# Altium schematic sheet HWSD-POL V1.0.SchDoc
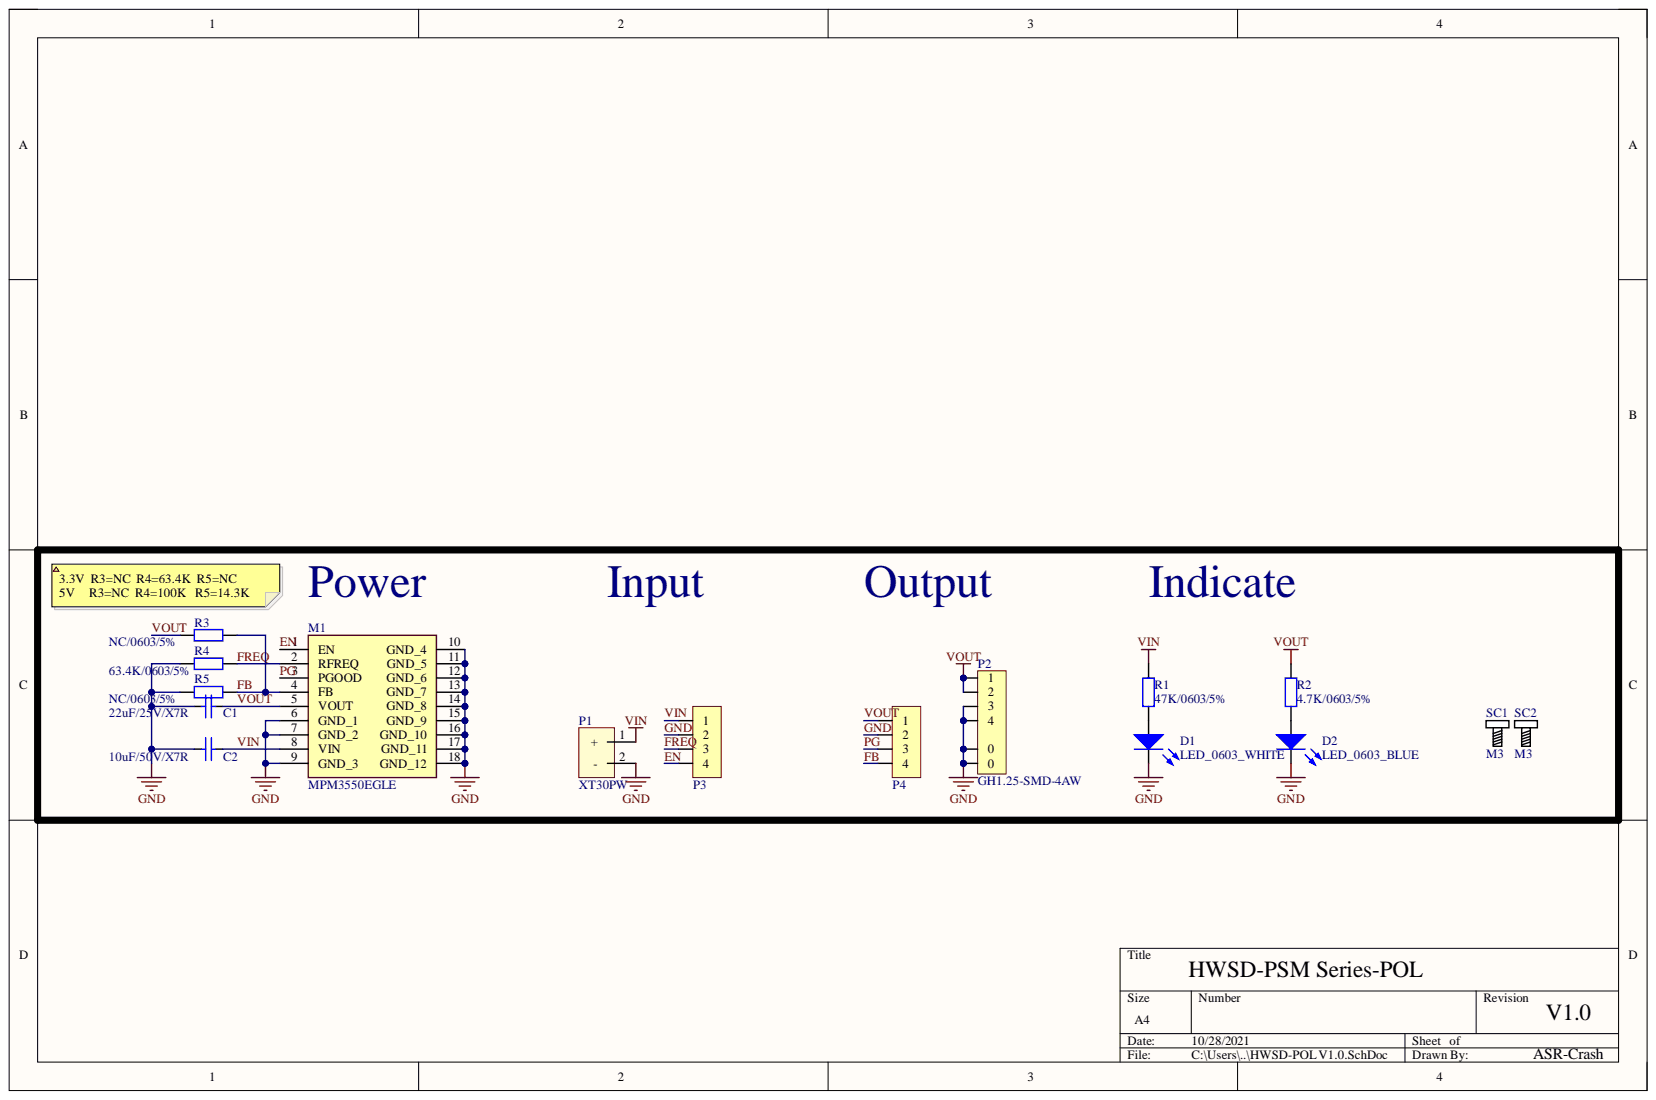
[diagram p0: HWSD-POL V1.0.SchDoc]

## Parts
C1  22uF/25V/X7R  [CAPC3225X270N]
C2  10uF/50V/X7R  [CAPC3225X270N]
D1  LED_0603_WHITE  [LED_0603_WHITE]
D2  LED_0603_BLUE  [LED_0603_BLUE]
M1  MPM3550EGLE  [MPM3550EGLE]
P1  XT30PW  [XT30PW-M]
P2  GH1.25-SMD-4AW  [GH1.25_4 卧贴]
P3  Header 4  [HDR1*4]  (value not drawn)
P4  Header 4  [HDR1*4]  (value not drawn)
R1  value 47K/0603/5%  [R-SMD-0603]  (hidden comment: Res_0603)
R2  value 4.7K/0603/5%  [R-SMD-0603]  (hidden comment: Res_0603)
R3  value NC/0603/5%  [R-SMD-0603]  (hidden comment: Res_0603)
R4  value 63.4K/0603/5%  [R-SMD-0603]  (hidden comment: Res_0603)
R5  value NC/0603/5%  [R-SMD-0603]  (hidden comment: Res_0603)
SC1  M3  [SCRREW_M3]
SC2  M3  [SCRREW_M3]

## Nets
EN: M1.1(EN) P3.4
FB: M1.4(FB) P4.4 R3.2 R5.2
FREQ: M1.2(RFREQ) P3.3 R4.2
GND: C1.1 C2.1 D1.2 D2.2 M1.6(GND_1) M1.7(GND_2) M1.9(GND_3) M1.10(GND_4) M1.11(GND_5) M1.12(GND_6) M1.13(GND_7) M1.14(GND_8) M1.15(GND_9) M1.16(GND_10) M1.17(GND_11) M1.18(GND_12) P1.2 P2.0 P2.3 P2.4 P3.2 P4.2 R4.1 R5.1
PG: M1.3(PGOOD) P4.3
VIN: C2.2 M1.8(VIN) P1.1 P3.1 R1.2
VOUT: C1.2 M1.5(VOUT) P2.1 P2.2 P4.1 R2.2 R3.1
NetD1_1: D1.1 R1.1
NetD2_1: D2.1 R2.1

## Library data of the parts
C1 = GRM32ER71E226KE15L: Capacitor; Manufacturer_Name: Murata Electronics; Arrow Part Number: GRM32ER71E226KE15L; Arrow Price/Stock: https://www.arrow.com/en/products/grm32er71e226ke15l/murata-manufacturing; Component Kind: Standard; Datasheet Link: http://psearch.en.murata.com/capacitor/product/GRM32ER71E226KE15%23.pdf; Height: 2.7mm; Manufacturer_Part_Number: GRM32ER71E226KE15L; Mouser Part Number: 81-GRM32ER71E226KE5L; Mouser Price/Stock: https://www.mouser.co.uk/ProductDetail/Murata-Electronics/GRM32ER71E226KE15L/?qs=xcCo%252BfWZmQXyfoWprNNimw%3D%3D; Library Name: Hardware Standardization Library.SchLib
C2 = GRM32ER71H106KA12L: Capacitor; Manufacturer_Name: Murata Electronics; Arrow Part Number: GRM32ER71H106KA12L; Arrow Price/Stock: https://www.arrow.com/en/products/grm32er71h106ka12l/murata-manufacturing; Component Kind: Standard; Datasheet Link: https://componentsearchengine.com/Datasheets/2/GRM32ER71H106KA12L.pdf; Height: 2.7mm; Manufacturer_Part_Number: GRM32ER71H106KA12L; Mouser Part Number: 81-GRM32ER71H106KA2L; Mouser Price/Stock: https://www.mouser.co.uk/ProductDetail/Murata-Electronics/GRM32ER71H106KA12L/?qs=6pxx44s1bjkjdm74o%2FrKhg%3D%3D; Library Name: Hardware Standardization Library.SchLib
D1 D2 = LED_0603: LED; Component Kind: Standard; Library Name: Hardware Standardization Library.SchLib
M1 = MPM3550EGLE: Power Module; Manufacturer_Name: Monolithic Power Systems (MPS); Component Kind: Standard; Datasheet Link: https://www.monolithicpower.com/en/documentview/productdocument/index/version/2/document_type/Datasheet/lang/en/sku/MPM3550EGLE/document_id/5102; Height: 4.35mm; Manufacturer_Part_Number: MPM3550EGLE; Mouser Part Number: 946-MPM3550EGLE; Mouser Price/Stock: https://www.mouser.co.uk/ProductDetail/Monolithic-Power-Systems-MPS/MPM3550EGLE?qs=r5DSvlrkXmIQI3GW7lf2xA%3D%3D; Library Name: Hardware Standardization Library.SchLib
P1 = XT30PW: Connector; Component Kind: Standard; Library Name: Hardware Standardization Library.SchLib
P2 = GH1.25-SMD-4AW: Interface; Component Kind: Standard; Library Name: Hardware Standardization Library.SchLib
P3 P4 = Header 4: Header; Component Kind: Standard; LatestRevisionDate: 17-Jul-2002; LatestRevisionNote: Re-released for DXP Platform.; Library Name: Hardware Standardization Library.SchLib; Publisher: Altium Limited
R1-R5 = Res_0603: Resistor; Component Kind: Standard; Library Name: Hardware Standardization Library.SchLib
SC1 SC2 = Screw_M3: Screw; Component Kind: Standard; Library Name: Hardware Standardization Library.SchLib
